# Altium schematic sheet Sheet1.SchDoc
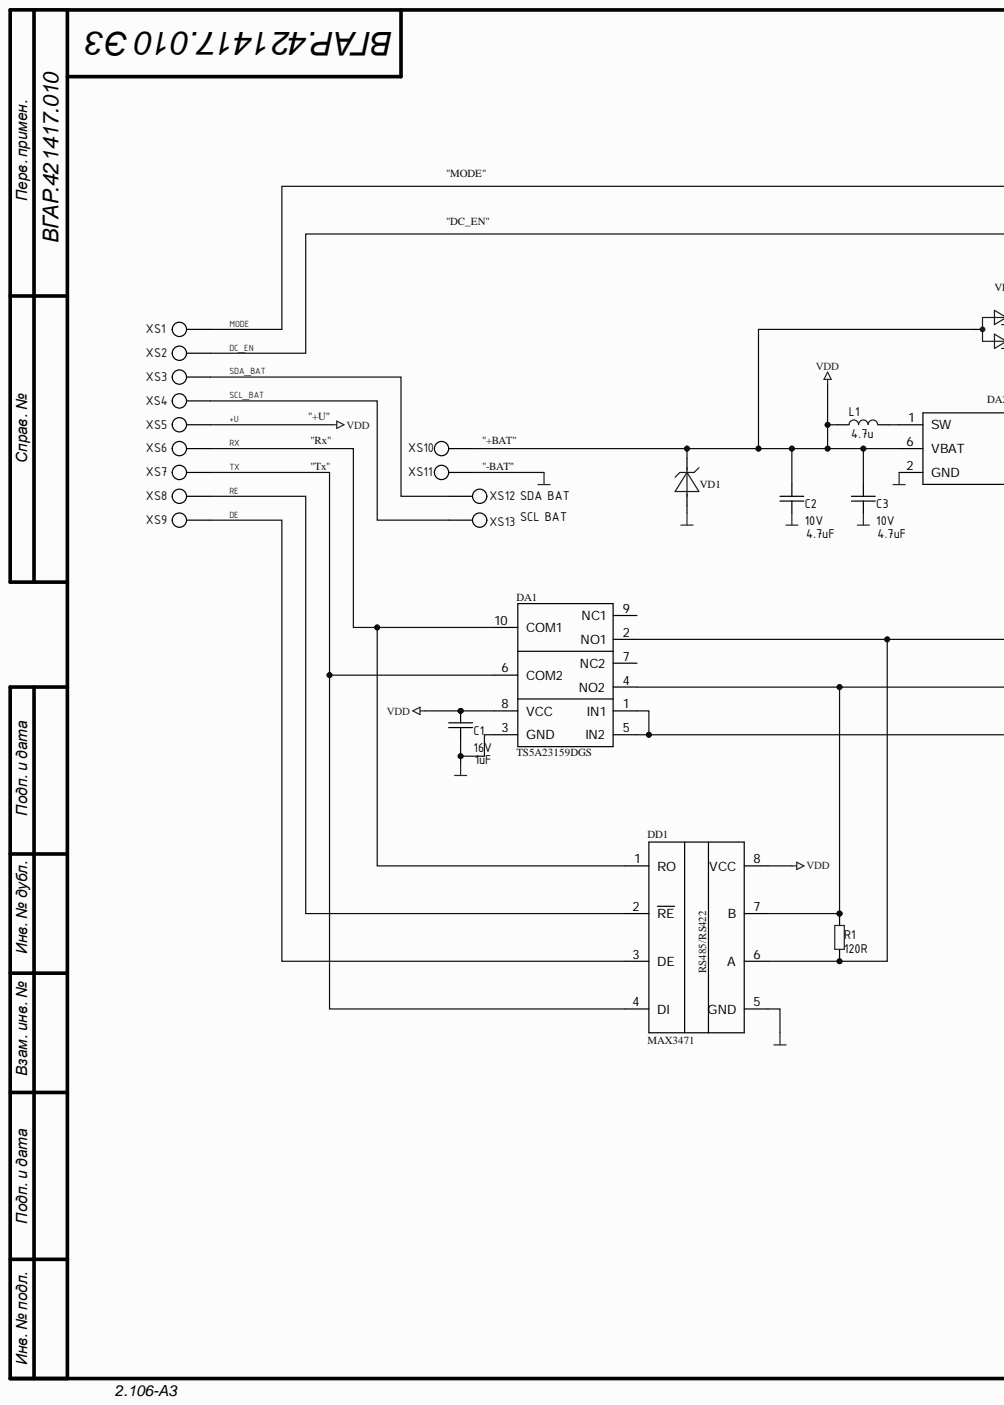
[diagram p0: Sheet1.SchDoc, left]
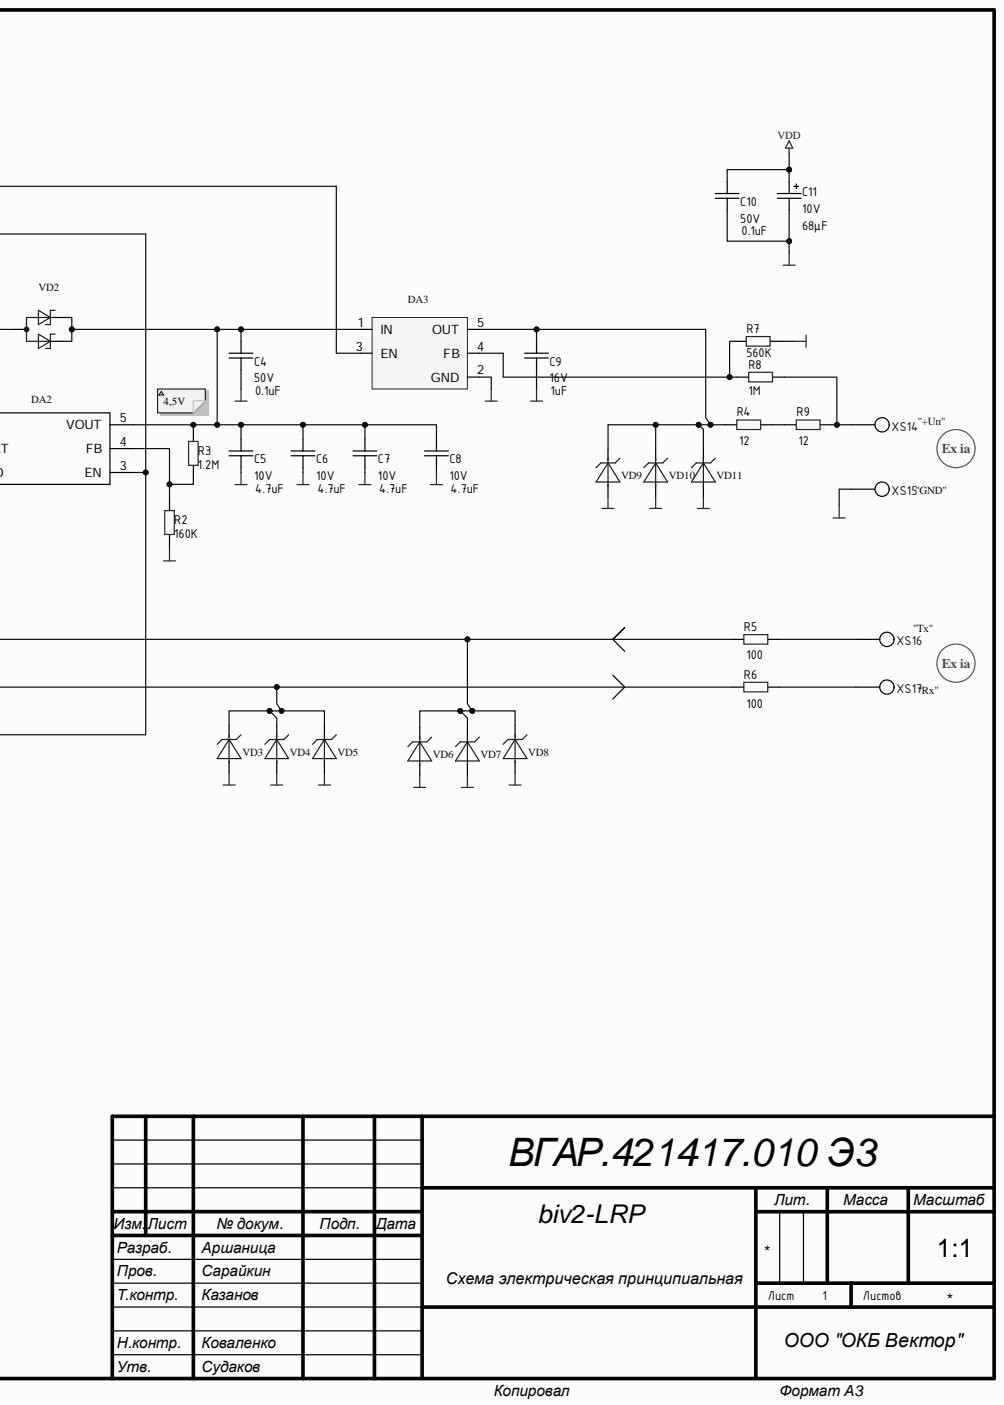
[diagram p1: Sheet1.SchDoc, right]

## Parts
C1  value 1uF  Voltage: 16V  [CAP: 0603]  (hidden comment: Ceramic capacitor, 1uF, X7R, ±10%, 16V, 0603, -55...+125°C)
C2  value 4.7uF  Voltage: 10V  [CAP: 0603]  (hidden comment: Ceramic capacitor, 4.7uF, X7R, ±10%, 10V, 0603, -55...+125°C)
C3  value 4.7uF  Voltage: 10V  [CAP: 0603]  (hidden comment: Ceramic capacitor, 4.7uF, X7R, ±10%, 10V, 0603, -55...+125°C)
C4  value 0.1uF  Voltage: 50V  [CAP: 0603]  (hidden comment: Ceramic capacitor, 0.1uF, X7R, ±10%, 50V, 0603, -55...+125°C)
C5  value 4.7uF  Voltage: 10V  [CAP: 0603]  (hidden comment: Ceramic capacitor, 4.7uF, X7R, ±10%, 10V, 0603, -55...+125°C)
C6  value 4.7uF  Voltage: 10V  [CAP: 0603]  (hidden comment: Ceramic capacitor, 4.7uF, X7R, ±10%, 10V, 0603, -55...+125°C)
C7  value 4.7uF  Voltage: 10V  [CAP: 0603]  (hidden comment: Ceramic capacitor, 4.7uF, X7R, ±10%, 10V, 0603, -55...+125°C)
C8  value 4.7uF  Voltage: 10V  [CAP: 0603]  (hidden comment: Ceramic capacitor, 4.7uF, X7R, ±10%, 10V, 0603, -55...+125°C)
C9  value 1uF  Voltage: 16V  [CAP: 0603]  (hidden comment: Ceramic capacitor, 1uF, X7R, ±10%, 16V, 0603, -55...+125°C)
C10  value 0.1uF  Voltage: 50V  [CAP: 0603]  (hidden comment: Ceramic capacitor, 0.1uF, X7R, ±10%, 50V, 0603, -55...+125°C)
C11  value 68μF  Voltage: 10V  [CAP: TANTAL-B]  (hidden comment: Tantalum capacitor, 68μF, 10V, ±10%, 1.2Ohm, case B (3528), -55...+125°C)
DA1  TS5A23159DGS  [VSSSOP-10 - TI]
DA2  TPS6107x  [SOT23-6]  (value not drawn)
DA3  TPS78001DDC  [SOT23-5]  (value not drawn)
DD1  MAX3471  [MSOP-8]
L1  value 4.7u  [IND: CDRH4D28NP]  (hidden comment: CDRH4D)
R1  value 120R  [RES: 0603]  (hidden comment: Resistor film, 120R, ±5%, 0603, 0.1W, -55...+125°C)
R2  value 160K  [RES: 0603]  (hidden comment: Resistor film, 160K, ±5%, 0603, 0.1W, -55...+125°C)
R3  value 1.2M  [RES: 0603]  (hidden comment: Resistor film, 1.2M, ±5%, 0603, 0.1W, -55...+125°C)
R4  value 12  [RES: 2512]  (hidden comment: Resistor film, 12, ±5%, 2512, 1W, -55...+125°C)
R5  value 100  [RES: 2512]  (hidden comment: Resistor film, 100, ±5%, 2512, 1W, -55...+125°C)
R6  value 100  [RES: 2512]  (hidden comment: Resistor film, 100, ±5%, 2512, 1W, -55...+125°C)
R7  value 560K  [RES: 0603]  (hidden comment: Resistor film, 560K, ±5%, 0603, 0.1W, -55...+125°C)
R8  value 1M  [RES: 0603]  (hidden comment: Resistor film, 1M, ±5%, 0603, 0.1W, -55...+125°C)
R9  value 12  [RES: 2512]  (hidden comment: Resistor film, 12, ±5%, 2512, 1W, -55...+125°C)
VD1  SM2T  [DO216-AA]  (value not drawn)
VD2  BAT54AW  [SC-70]  (value not drawn)
VD3  SM2T  [DO216-AA]  (value not drawn)
VD4  SM2T  [DO216-AA]  (value not drawn)
VD5  SM2T  [DO216-AA]  (value not drawn)
VD6  SM2T  [DO216-AA]  (value not drawn)
VD7  SM2T  [DO216-AA]  (value not drawn)
VD8  SM2T  [DO216-AA]  (value not drawn)
VD9  SM2T  [DO216-AA]  (value not drawn)
VD10  SM2T  [DO216-AA]  (value not drawn)
VD11  SM2T  [DO216-AA]  (value not drawn)
XS1  Testpoint_HS1mm  [TestPoint(without 3D)]  (value not drawn)
XS2  Testpoint_HS1mm  [TestPoint(without 3D)]  (value not drawn)
XS3  Testpoint_HS1mm  [TestPoint(without 3D)]  (value not drawn)
XS4  Testpoint_HS1mm  [TestPoint(without 3D)]  (value not drawn)
XS5  Testpoint_HS1mm  [TestPoint(without 3D)]  (value not drawn)
XS6  Testpoint_HS1mm  [TestPoint(without 3D)]  (value not drawn)
XS7  Testpoint_HS1mm  [TestPoint(without 3D)]  (value not drawn)
XS8  Testpoint_HS1mm  [TestPoint(without 3D)]  (value not drawn)
XS9  Testpoint_HS1mm  [TestPoint(without 3D)]  (value not drawn)
XS10  Testpoint_HS1mm  [TestPoint(without 3D)]  (value not drawn)
XS11  Testpoint_HS1mm  [TestPoint(without 3D)]  (value not drawn)
XS12  Testpoint_HS1mm  [TestPoint(without 3D)]  (value not drawn)
XS13  Testpoint_HS1mm  [TestPoint(without 3D)]  (value not drawn)
XS14  Testpoint_HS1mm  [TestPoint(without 3D)]  (value not drawn)
XS15  Testpoint_HS1mm  [TestPoint(without 3D)]  (value not drawn)
XS16  Testpoint_HS1mm  [TestPoint(without 3D)]  (value not drawn)
XS17  Testpoint_HS1mm  [TestPoint(without 3D)]  (value not drawn)

## Nets
GND: C1.1 C2.1 C3.1 C4.1 C5.1 C6.1 C7.1 C8.1 C9.1 C10.1 C11.2 DA1.3(GND) DA2.2(GND) DA3.2(GND) DD1.5(GND) R2.1 R7.1 VD1.2 VD3.2 VD4.2 VD5.2 VD6.2 VD7.2 VD8.2 VD9.2 VD10.2 VD11.2 XS11.1 XS15.1
+U: C1.2 C2.2 C3.2 C10.2 C11.1 DA1.8(VCC) DA2.6(VBAT) DD1.8(VCC) L1.1 VD1.1 VD2.3 XS5.1 XS10.1
DC_EN: DA1.1(IN1) DA1.5(IN2) DA2.3(EN) XS2.1
DE: DD1.3(DE) XS9.1
MODE: DA3.3(EN) XS1.1
RE: DD1.2(RE) XS8.1
RX: DA1.10(COM1) DD1.1(RO) XS6.1
SCL_BAT: XS4.1 XS13.1
SDA_BAT: XS3.1 XS12.1
TX: DA1.6(COM2) DD1.4(DI) XS7.1
NetC4_2: C4.2 C5.2 C6.2 C7.2 C8.2 DA2.5(VOUT) DA3.1(IN) R3.2 VD2.1 VD2.2
NetC9_2: C9.2 DA3.5(OUT) R4.1 VD9.1 VD10.1 VD11.1
NetDA1_2: DA1.2(NO1) DD1.6(A) R1.1 R5.1 VD6.1 VD7.1 VD8.1
NetDA1_4: DA1.4(NO2) DD1.7(B) R1.2 R6.1 VD3.1 VD4.1 VD5.1
NetDA2_1: DA2.1(SW) L1.2
NetDA2_4: DA2.4(FB) R2.2 R3.1
NetDA3_4: DA3.4(FB) R7.2 R8.2
NetR4_2: R4.2 R9.1
NetR5_2: R5.2 XS16.1
NetR6_2: R6.2 XS17.1
NetR8_1: R8.1 R9.2 XS14.1

Not connected: DA1 7(NC2) 9(NC1)

## Library data of the parts
C1-C10 = Ceramic_0603: Manufacturer: Yageo; PartNumber: CC0603KRX7R7BB104; Component Kind: Standard; HelpURL: https://www.digikey.com/product-detail/en/yageo/CC0603KRX7R7BB104/311-1088-1-ND/302998; Library Name: capacitor.IntLib; Package: 0603; Temperature: -55...+125°C; Tolerance: ±10%; TypeCapacitor: Ceramic capacitor; TypeDielectric: X7R
  C1: Value: 1uF; ValueManufacturerPartNumber: Ceramic capacitor, 1uF, X7R, ±10%, 16V, 0603, -55...+125°C
  C2 C3 C5-C8: ValueManufacturerPartNumber: Ceramic capacitor, 4.7uF, X7R, ±10%, 10V, 0603, -55...+125°C
  C4 C10: ValueManufacturerPartNumber: Ceramic capacitor, 0.1uF, X7R, ±10%, 50V, 0603, -55...+125°C
  C9: ValueManufacturerPartNumber: Ceramic capacitor, 1uF, X7R, ±10%, 16V, 0603, -55...+125°C
C11 = Tantal_B: Manufacturer: AVX; PartNumber: F930J227KBA; TypeDielectric: X7R; Component Kind: Standard; HelpURL: https://www.digikey.com/product-detail/en/avx-corporation/F930J227KBA/478-8166-1-ND/4005570; Impedance: 1.2Ohm; Library Name: capacitor.IntLib; Package: case B (3528); Temperature: -55...+125°C; Tolerance: ±10%; TypeCapacitor: Tantalum capacitor; ValueManufacturerPartNumber: Tantalum capacitor, 68μF, 10V, ±10%, 1.2Ohm, case B (3528), -55...+125°C
DA1 = TS5A23159DGS: Микросхема; Manufacturer: Texas Instruments; Component Kind: Standard; Library Name: TI Analog Switch.IntLib; PartNumber: TS5A23159DGS
DA2 = TPS61070: Микросхема; Manufacturer: Texas Instruments; Component Kind: Standard; ComponentLink1Description: Data Sheet; PartNumber: TPS6107x; Library Name: TI Power Mgt Switchmode Regulator.IntLib
DA3 = TPS78001DDC: Микросхема; Manufacturer: Texas Instruments; Part Number: TPS780270200DDCT; Component Kind: Standard; ComponentLink1Description: Data Sheet; ComponentLink1URL: http://focus.ti.com/lit/ds/symlink/tps780270200.pdf; Library Name: TI LDO.IntLib
DD1 = MAX3471: RS-485/RS422Half-Duplex,  Differential Transceiver for Battery-Powered Systems; Manufacturer: MAXIM; Component Kind: Standard; ComponentLink1Description: Data Sheet; ComponentLink1URL: \\Mskd20virt\KB\PDF\Интерфейсы\RS232_485\MAX3471.pdf; ComponentLink2Description: Replacement 1 Data Sheet; Library Name: Maxim Interface.IntLib; PartNumber: MAX3471
L1 = CDRH4D28NP: Component Kind: Standard; ComponentLink1Description: Datasheet; ComponentLink1URL: https://products.sumida.com/products/pdf/CDRH4D28.pdf; Library Name: inductor.IntLib
R1-R3 R7 R8 = Resistor_0603: Manufacturer: Yageo; PartNumber: RC0603JR-07100RL; Component Kind: Standard; HelpURL: https://www.digikey.com/product-detail/en/yageo/RC0603JR-07100RL/311-100GRCT-ND/729644; Library Name: resistor.IntLib; Package: 0603; Power: 0.1W; Temperature: -55...+125°C; Tolerance: ±5%; TypeResistor: Resistor film
  R1: ValueManufacturerPartNumber: Resistor film, 120R, ±5%, 0603, 0.1W, -55...+125°C
  R2: ValueManufacturerPartNumber: Resistor film, 160K, ±5%, 0603, 0.1W, -55...+125°C
  R3: ValueManufacturerPartNumber: Resistor film, 1.2M, ±5%, 0603, 0.1W, -55...+125°C
  R7: ValueManufacturerPartNumber: Resistor film, 560K, ±5%, 0603, 0.1W, -55...+125°C
  R8: ValueManufacturerPartNumber: Resistor film, 1M, ±5%, 0603, 0.1W, -55...+125°C
R4-R6 R9 = Resistor_2512: Manufacturer: Yageo; PartNumber: RC2512JK-07100RL; Component Kind: Standard; HelpURL: https://www.digikey.com/product-detail/en/yageo/RC2512JK-07100RL/YAG3393CT-ND/5962215; Library Name: resistor.IntLib; Package: 2512; Power: 1W; Temperature: -55...+125°C; Tolerance: ±5%; TypeResistor: Resistor film
  R4 R9: ValueManufacturerPartNumber: Resistor film, 12, ±5%, 2512, 1W, -55...+125°C
  R5 R6: ValueManufacturerPartNumber: Resistor film, 100, ±5%, 2512, 1W, -55...+125°C
VD1 VD3-VD11 = SM2T: TVS Диод; Manufacturer: ST Microelectronics; ComponentLink1Descriprion: Data Sheet; Component Kind: Standard; ComponentLink2Description: Replacement 1 Data Sheet; ComponentLink3Description: Replacement 2 Data Sheet; Library Name: ST Protection Diodes.IntLib
VD2 = BAT54AW: Сборка диодная; Manufacturer: NXP; ReplacementPart1Manufacturer: ON Semiconductor; ReplacementPart1PartNumber: BAT54AWT1G; ReplacementPart1TopMarking: B7*; ReplacementPart2Manufacturer: Infineon; ReplacementPart2PartNumber: BAT54-06W; ReplacementPart2TopMarking: TA; TopMarking: 42; Component Kind: Standard; ComponentLink1Description: DataSheet; ComponentLink1URL: http://www.nxp.com/documents/data_sheet/BAT54W.pdf; ComponentLink2Description: Replacement1DataSheet; ComponentLink2URL: http://www.onsemi.com/pub_link/Collateral/BAT54AWT1-D.PDF; ComponentLink3Description: Replacement2DataSheet; ComponentLink3URL: http://www.infineon.com/dgdl/bat54series.pdf?folderId=db3a30431400ef68011425f1ca2505e3&fileId=db3a304314dca3890115190b74210ea6; Library Name: NXP Diodes.IntLib; Package: SC-70; PartNumber: BAT54AW
XS1-XS17 = Testpoint_HS1mm: Component Kind: Standard; Library Name: connector.IntLib
